# Altium schematic sheet Output_Connectors.SchDoc
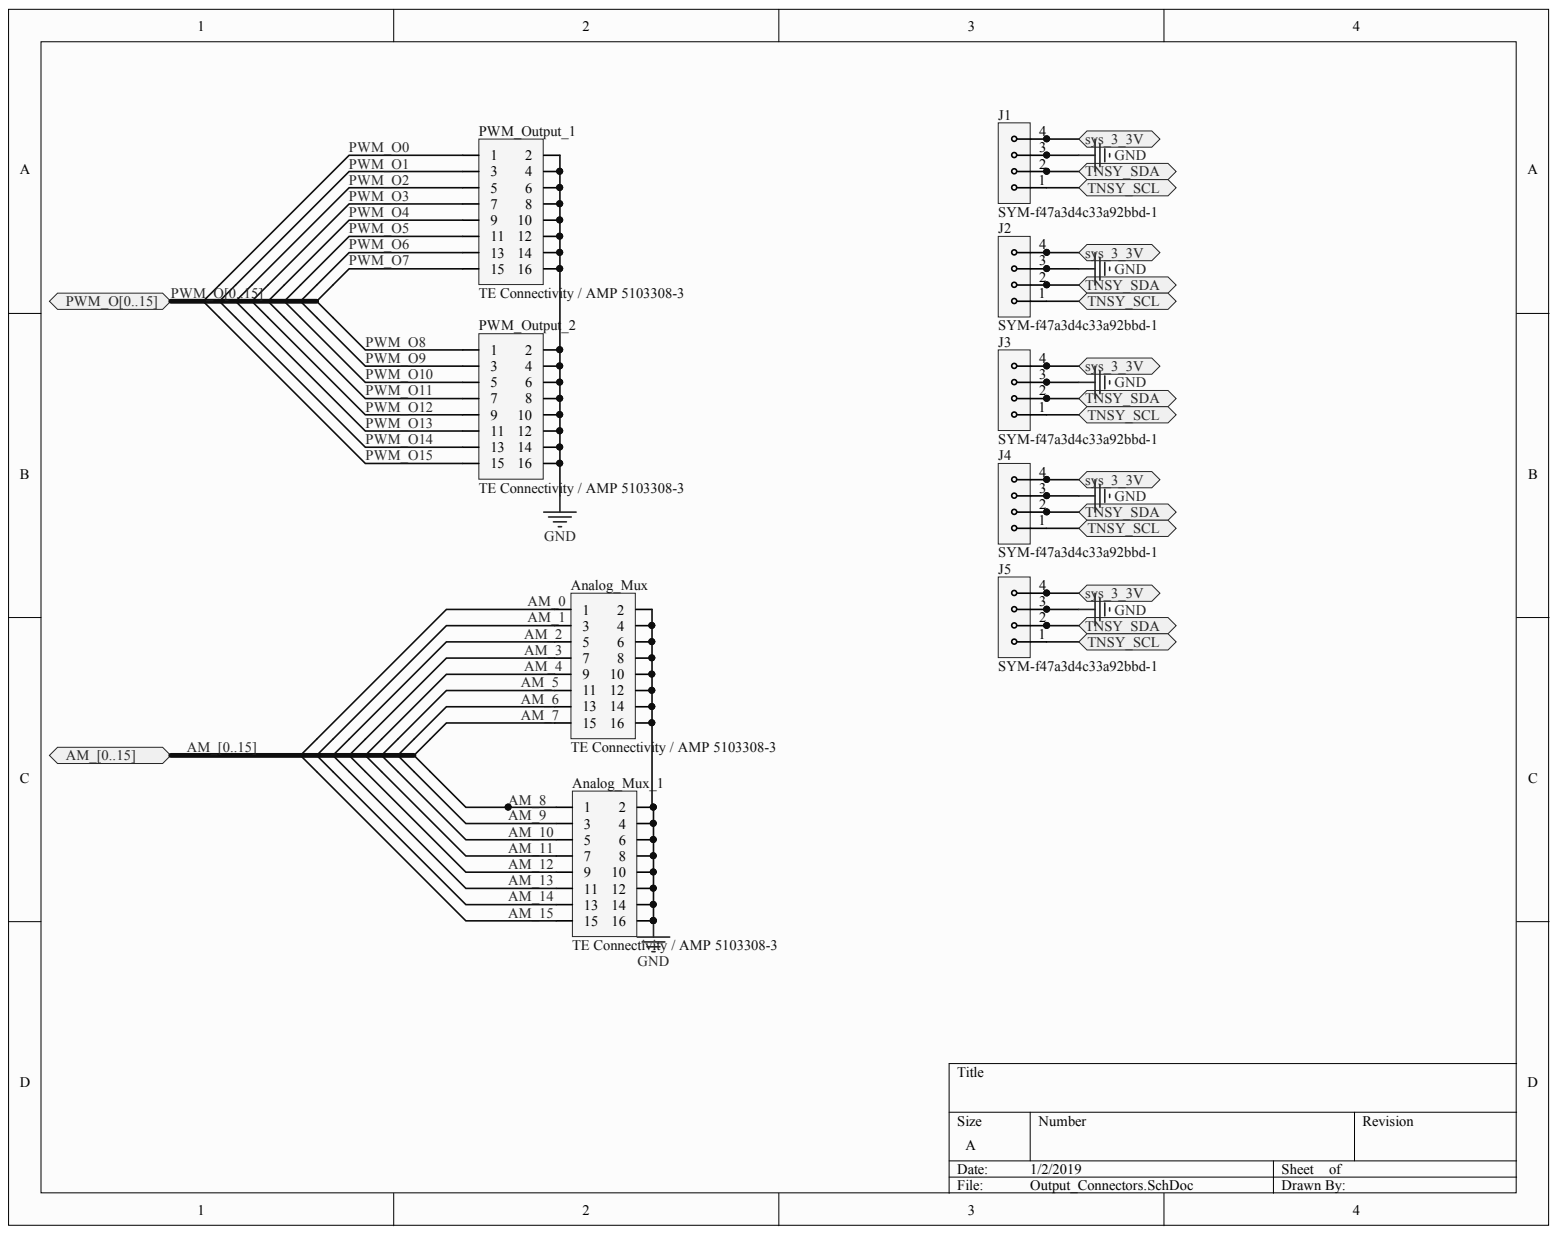
[diagram p0: Output_Connectors.SchDoc]

## Parts
Analog_Mux  TE Connectivity / AMP 5103308-3  [PCB-ez7c9rqqhu21cqzcwvs2-1]
Analog_Mux_1  TE Connectivity / AMP 5103308-3  [PCB-ez7c9rqqhu21cqzcwvs2-1]
J1  SYM-f47a3d4c33a92bbd-1  [PCBComponent_1]
J2  SYM-f47a3d4c33a92bbd-1  [PCBComponent_1]
J3  SYM-f47a3d4c33a92bbd-1  [PCBComponent_1]
J4  SYM-f47a3d4c33a92bbd-1  [PCBComponent_1]
J5  SYM-f47a3d4c33a92bbd-1  [PCBComponent_1]
PWM_Output_1  TE Connectivity / AMP 5103308-3  [PCB-ez7c9rqqhu21cqzcwvs2-1]
PWM_Output_2  TE Connectivity / AMP 5103308-3  [PCB-ez7c9rqqhu21cqzcwvs2-1]

## Ports
AM_0, AM_1, AM_2, AM_3, AM_4, AM_5, AM_6, AM_7, AM_8, AM_9, AM_10, AM_11, AM_12, AM_13, AM_14, AM_15, AM_[0..15], PWM_O0, PWM_O1, PWM_O2, PWM_O3, PWM_O4, PWM_O5, PWM_O6, PWM_O7, PWM_O8, PWM_O9, PWM_O10, PWM_O11, PWM_O12, PWM_O13, PWM_O14, PWM_O15, PWM_O[0..15], SYS_3_3V, TNSY_SCL, TNSY_SDA

## Nets
GND: Analog_Mux.2 Analog_Mux.4 Analog_Mux.6 Analog_Mux.8 Analog_Mux.10 Analog_Mux.12 Analog_Mux.14 Analog_Mux.16 Analog_Mux_1.2 Analog_Mux_1.4 Analog_Mux_1.6 Analog_Mux_1.8 Analog_Mux_1.10 Analog_Mux_1.12 Analog_Mux_1.14 Analog_Mux_1.16 J1.3 J2.3 J3.3 J4.3 J5.3 PWM_Output_1.2 PWM_Output_1.4 PWM_Output_1.6 PWM_Output_1.8 PWM_Output_1.10 PWM_Output_1.12 PWM_Output_1.14 PWM_Output_1.16 PWM_Output_2.2 PWM_Output_2.4 PWM_Output_2.6 PWM_Output_2.8 PWM_Output_2.10 PWM_Output_2.12 PWM_Output_2.14 PWM_Output_2.16
AM_0: Analog_Mux.1 ; port AM_[0..15]  [also labelled AM_]
AM_1: Analog_Mux.3 ; port AM_[0..15]  [also labelled AM_]
AM_2: Analog_Mux.5 ; port AM_[0..15]  [also labelled AM_]
AM_3: Analog_Mux.7 ; port AM_[0..15]  [also labelled AM_]
AM_4: Analog_Mux.9 ; port AM_[0..15]  [also labelled AM_]
AM_5: Analog_Mux.11 ; port AM_[0..15]  [also labelled AM_]
AM_6: Analog_Mux.13 ; port AM_[0..15]  [also labelled AM_]
AM_7: Analog_Mux.15 ; port AM_[0..15]  [also labelled AM_]
AM_8: Analog_Mux_1.1 ; port AM_[0..15]  [also labelled AM_]
AM_9: Analog_Mux_1.3 ; port AM_[0..15]  [also labelled AM_]
AM_10: Analog_Mux_1.5 ; port AM_[0..15]  [also labelled AM_]
AM_11: Analog_Mux_1.7 ; port AM_[0..15]  [also labelled AM_]
AM_12: Analog_Mux_1.9 ; port AM_[0..15]  [also labelled AM_]
AM_13: Analog_Mux_1.11 ; port AM_[0..15]  [also labelled AM_]
AM_14: Analog_Mux_1.13 ; port AM_[0..15]  [also labelled AM_]
AM_15: Analog_Mux_1.15 ; port AM_[0..15]  [also labelled AM_]
PWM_O0: PWM_Output_1.1 ; port PWM_O[0..15]  [also labelled PWM_O]
PWM_O1: PWM_Output_1.3 ; port PWM_O[0..15]  [also labelled PWM_O]
PWM_O2: PWM_Output_1.5 ; port PWM_O[0..15]  [also labelled PWM_O]
PWM_O3: PWM_Output_1.7 ; port PWM_O[0..15]  [also labelled PWM_O]
PWM_O4: PWM_Output_1.9 ; port PWM_O[0..15]  [also labelled PWM_O]
PWM_O5: PWM_Output_1.11 ; port PWM_O[0..15]  [also labelled PWM_O]
PWM_O6: PWM_Output_1.13 ; port PWM_O[0..15]  [also labelled PWM_O]
PWM_O7: PWM_Output_1.15 ; port PWM_O[0..15]  [also labelled PWM_O]
PWM_O8: PWM_Output_2.1 ; port PWM_O[0..15]  [also labelled PWM_O]
PWM_O9: PWM_Output_2.3 ; port PWM_O[0..15]  [also labelled PWM_O]
PWM_O10: PWM_Output_2.5 ; port PWM_O[0..15]  [also labelled PWM_O]
PWM_O11: PWM_Output_2.7 ; port PWM_O[0..15]  [also labelled PWM_O]
PWM_O12: PWM_Output_2.9 ; port PWM_O[0..15]  [also labelled PWM_O]
PWM_O13: PWM_Output_2.11 ; port PWM_O[0..15]  [also labelled PWM_O]
PWM_O14: PWM_Output_2.13 ; port PWM_O[0..15]  [also labelled PWM_O]
PWM_O15: PWM_Output_2.15 ; port PWM_O[0..15]  [also labelled PWM_O]
TNSY_SCL: J1.1 J2.1 J3.1 J4.1 J5.1 ; port TNSY_SCL
TNSY_SDA: J1.2 J2.2 J3.2 J4.2 J5.2 ; port TNSY_SDA
NetJ1_4: J1.4 J2.4 J3.4 J4.4 J5.4 ; port SYS_3_3V

## Library data of the parts
Analog_Mux Analog_Mux_1 PWM_Output_1 PWM_Output_2 = CMP-697ba22bb0a4b6bc-2: Wire-To-Board Connector, AMP-LATCH Series, Through Hole, Header, 16, 2.54 mm, Gold Plated Contacts; Pin Pitch: 2.54 mm; Color: Black; Component Kind: Standard; Contact Plating: Gold Flash, Tin over Nickel, Tin; Housing Color: Black; Housing Material: Thermoplastic; Library Name: CommunityVault; Mounting Style: Through Hole, Vertical; Octopart URL: http://octopart.com/5103308-3-te+connectivity+%2F+amp-39517369; Size-Height: 9.78 mm
J1-J5 = CMP-f47a3d4c33a92bbd-2: Socket, wire-board, male, XH, 2.5mm, PIN: 4, THT, 250V 3A, -25ºC to +85ºC; Manufacturer Part Number: B4B-XH-A; Component Kind: Standard; Contact Material: Phosphor Bronze; Library Name: CommunityVault; Octopart URL: https://octopart.com/b4b-xh-a-jst-3990197; Orientation: Straight; RoHS: Non-Compliant
